# Altium schematic sheet 0_MCU_And_Uart.SchDoc
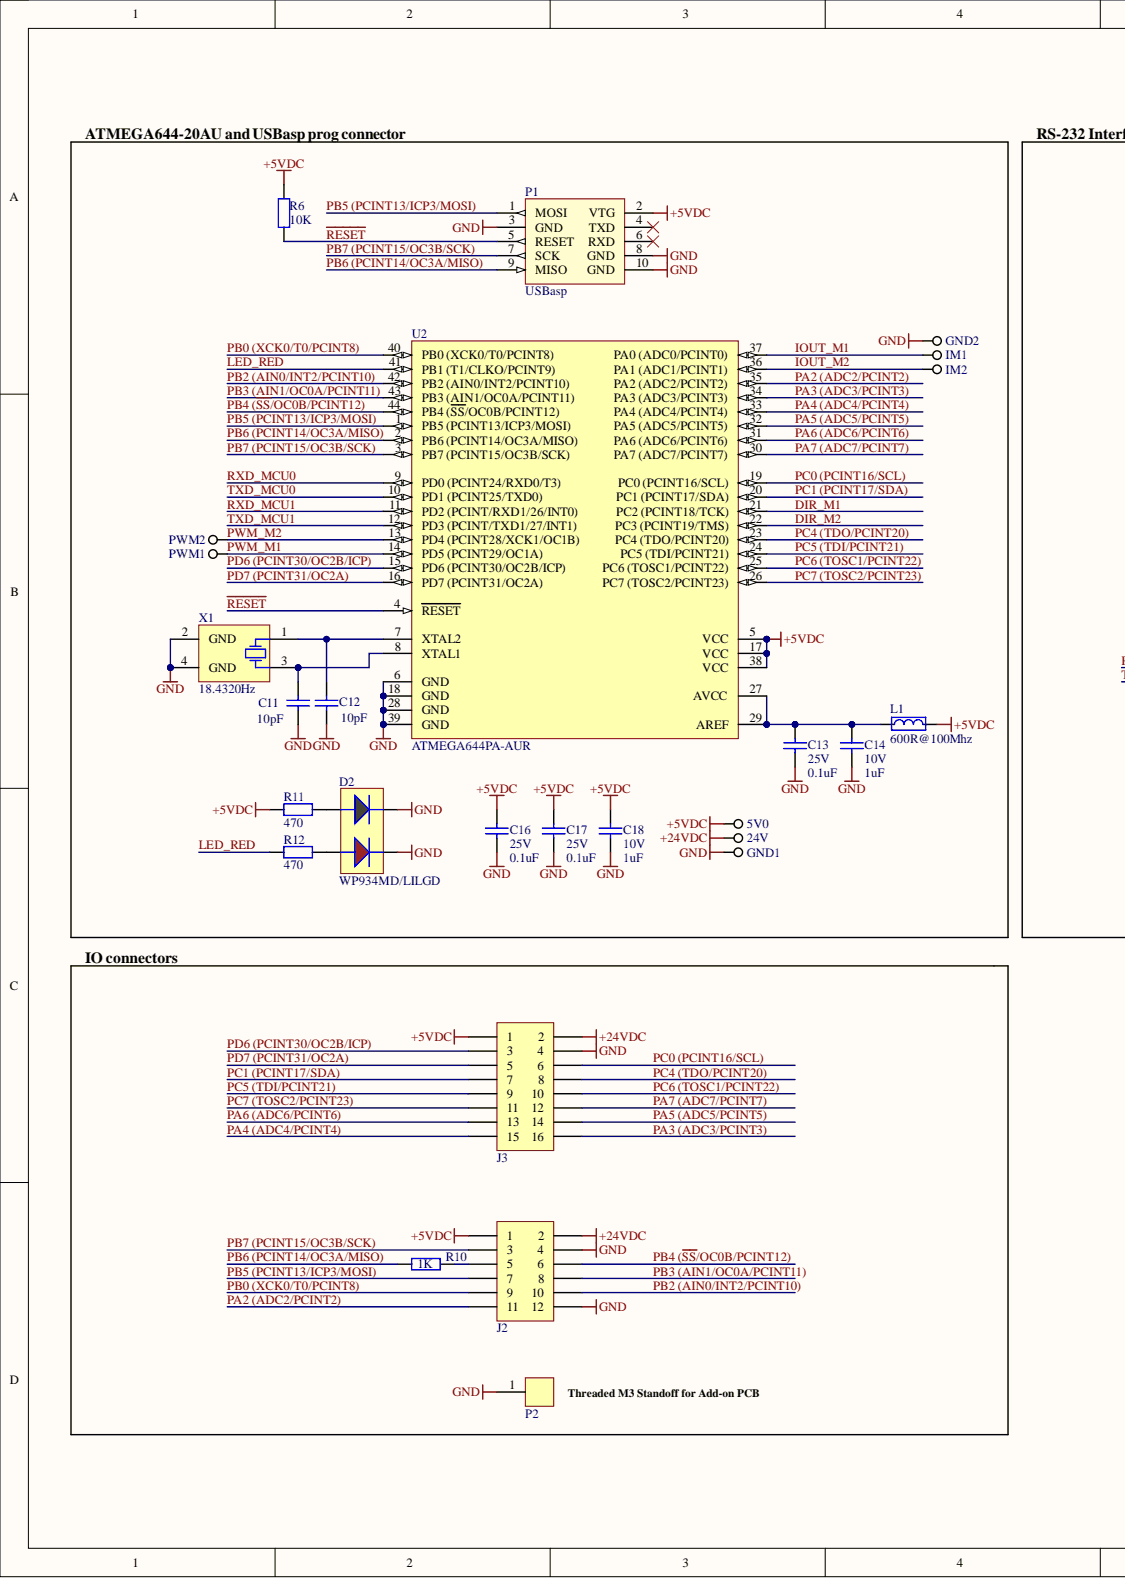
[diagram p0: 0_MCU_And_Uart.SchDoc, left]
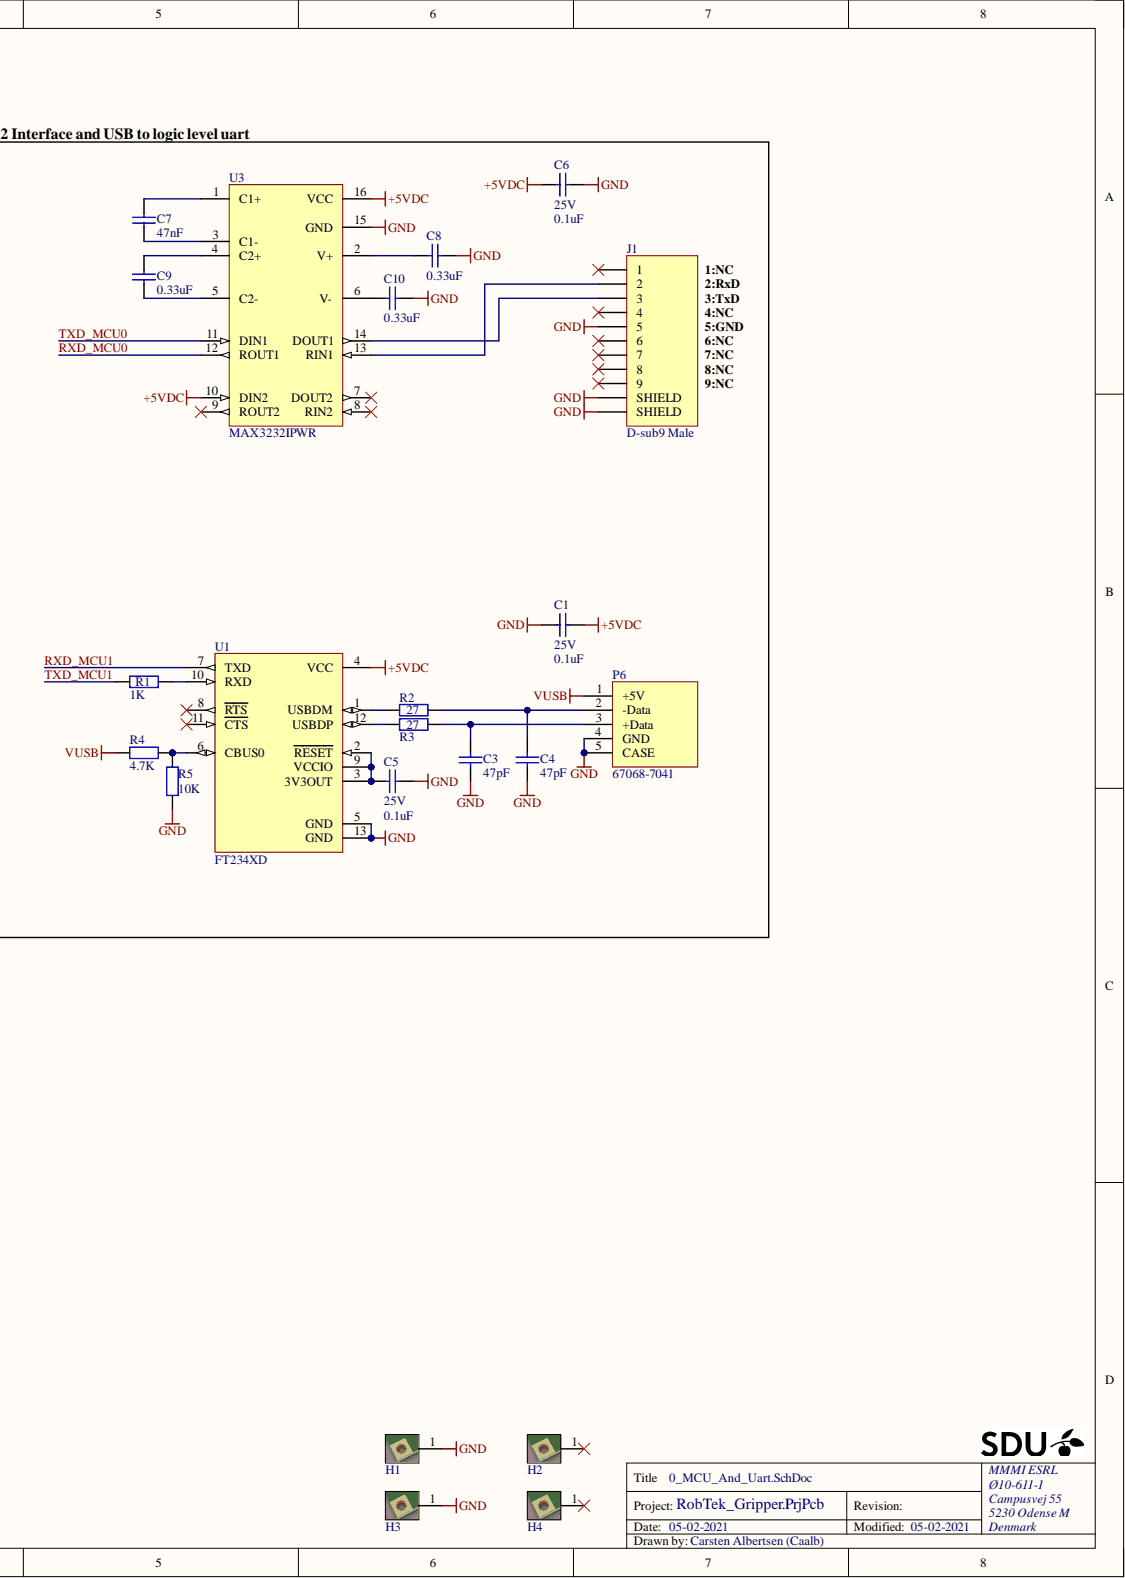
[diagram p1: 0_MCU_And_Uart.SchDoc, right]

## Parts
5V0  Test point SMD  [TestPointPadSMD_1mm]  (value not drawn)  (no BOM)
24V  Test point SMD  [TestPointPadSMD_1mm]  (value not drawn)  (no BOM)
C1  25V  value 0.1uF  [0603C]
C3  value 47pF  [0603C]  (hidden comment: 50V)
C4  value 47pF  [0603C]  (hidden comment: 50V)
C5  25V  value 0.1uF  [0603C]
C6  25V  value 0.1uF  [0603C]
C7  value 47nF  [0603C]  (hidden comment: 50V)
C8  value 0.33uF  [0603C]  (hidden comment: 50V)
C9  value 0.33uF  [0603C]  (hidden comment: 50V)
C10  value 0.33uF  [0603C]  (hidden comment: 50V)
C11  value 10pF  [0603C]  (hidden comment: 50V)
C12  value 10pF  [0603C]  (hidden comment: 50V)
C13  25V  value 0.1uF  [0603C]
C14  10V  value 1uF  [0603C]
C16  25V  value 0.1uF  [0603C]
C17  25V  value 0.1uF  [0603C]
C18  10V  value 1uF  [0603C]
D2  WP934MD/LILGD  [WP934MD/LILGD]
GND1  Test point SMD  [TestPointPadSMD_1mm]  (value not drawn)  (no BOM)
GND2  Test point SMD  [TestPointPadSMD_1mm]  (value not drawn)  (no BOM)
H1  PCB Hole  [Hole 3mm]  (value not drawn)  (no BOM)
H2  PCB Hole  [Hole 3mm]  (value not drawn)  (no BOM)
H3  PCB Hole  [Hole 3mm]  (value not drawn)  (no BOM)
H4  PCB Hole  [Hole 3mm]  (value not drawn)  (no BOM)
IM1  Test point SMD  [TestPointPadSMD_1mm]  (value not drawn)  (no BOM)
IM2  Test point SMD  [TestPointPadSMD_1mm]  (value not drawn)  (no BOM)
J1  D-sub9 Male  [A-DS 09 A/KG-T2S]
J2  2x6 Header  [HDR2X6 Receptacles]  (value not drawn)
J3  2x8 Header  [HDR2X8 Receptacles]  (value not drawn)
L1  600R@100Mhz  [0603L]
P1  USBasp  [HDR2X5 FLAD 90DEG 30310-5002HB]
P2  BUSH, M3, SMT  [9774110360R]  (value not drawn)
P6  67068-7041  [67068-7041]
PWM1  Test point SMD  [TestPointPadSMD_1mm]  (value not drawn)  (no BOM)
PWM2  Test point SMD  [TestPointPadSMD_1mm]  (value not drawn)  (no BOM)
R1  1%  value 1K  [0603R]
R2  value 27  [0603R]  (hidden comment: 1%)
R3  value 27  [0603R]  (hidden comment: 1%)
R4  value 4.7K  [0603R]  (hidden comment: 1%)
R5  1%  value 10K  [0603R]
R6  1%  value 10K  [0603R]
R10  1%  value 1K  [0603R]
R11  value 470  [0603R]  (hidden comment: 1%)
R12  value 470  [0603R]  (hidden comment: 1%)
U1  FT234XD  [DFN12 3X3]
U2  ATMEGA644PA-AUR  [TQFP44]
U3  MAX3232IPWR  [tssop16]
X1  18.4320Hz  [TSX-3225]

## Nets
+5VDC: 5V0.1 C1.2 C6.1 C16.2 C17.2 C18.2 J2.1 J3.1 L1.2 P1.2(VTG) R6.2 R11.2 U1.4(VCC) U2.5(VCC) U2.17(VCC) U2.38(VCC) U3.10(DIN2) U3.16(VCC)
+24VDC: 24V.1 J2.2 J3.2
GND: C1.1 C3.1 C4.1 C5.1 C6.2 C8.1 C10.1 C11.1 C12.1 C13.1 C14.1 C16.1 C17.1 C18.1 D2.2 D2.4 GND1.1 GND2.1 H1.1 H3.1 J1.5 J1.10(SHIELD) J1.11(SHIELD) J2.4 J2.12 J3.4 P1.3(GND) P1.8(GND) P1.10(GND) P2.1 P6.4(GND) P6.5(CASE) R5.1 U1.5(GND) U1.13(GND) U2.6(GND) U2.18(GND) U2.28(GND) U2.39(GND) U3.15(GND) X1.2(GND) X1.4(GND)
VUSB: P6.1(+5V) R4.2
DIR_M1: U2.21(PC2_(PCINT18/TCK))
DIR_M2: U2.22(PC3_(PCINT19/TMS))
IOUT_M1: IM1.1 U2.37(PA0_(ADC0/PCINT0))
IOUT_M2: IM2.1 U2.36(PA1_(ADC1/PCINT1))
LED_RED: R12.2 U2.41(PB1_(T1/CLKO/PCINT9))
PA2 (ADC2/PCINT2): J2.11 U2.35(PA2_(ADC2/PCINT2))
PA3 (ADC3/PCINT3): J3.16 U2.34(PA3_(ADC3/PCINT3))
PA4 (ADC4/PCINT4): J3.15 U2.33(PA4_(ADC4/PCINT4))
PA5 (ADC5/PCINT5): J3.14 U2.32(PA5_(ADC5/PCINT5))
PA6 (ADC6/PCINT6): J3.13 U2.31(PA6_(ADC6/PCINT6))
PA7 (ADC7/PCINT7): J3.12 U2.30(PA7_(ADC7/PCINT7))
PB0 (XCK0/T0/PCINT8): J2.9 U2.40(PB0_(XCK0/T0/PCINT8))
PB2 (AIN0/INT2/PCINT10): J2.10 U2.42(PB2_(AIN0/INT2/PCINT10))
PB3 (AIN1/OC0A/PCINT11): J2.8 U2.43(PB3_(AIN1/OC0A/PCINT11))
PB4 (~{SS}/OC0B/PCINT12): J2.6 U2.44(PB4_(SS/OC0B/PCINT12))
PB5 (PCINT13/ICP3/MOSI): J2.7 P1.1(MOSI) U2.1(PB5_(PCINT13/ICP3/MOSI))
PB6 (PCINT14/OC3A/MISO): P1.9(MISO) R10.2 U2.2(PB6_(PCINT14/OC3A/MISO))
PB7 (PCINT15/OC3B/SCK): J2.3 P1.7(SCK) U2.3(PB7_(PCINT15/OC3B/SCK))
PC0 (PCINT16/SCL): J3.6 U2.19(PC0_(PCINT16/SCL))
PC1 (PCINT17/SDA): J3.7 U2.20(PC1_(PCINT17/SDA))
PC4 (TDO/PCINT20): J3.8 U2.23(PC4_(TDO/PCINT20))
PC5 (TDI/PCINT21): J3.9 U2.24(PC5_(TDI/PCINT21))
PC6 (TOSC1/PCINT22): J3.10 U2.25(PC6_(TOSC1/PCINT22))
PC7 (TOSC2/PCINT23): J3.11 U2.26(PC7_(TOSC2/PCINT23))
PD6 (PCINT30/OC2B/ICP): J3.3 U2.15(PD6_(PCINT30/OC2B/ICP))
PD7 (PCINT31/OC2A): J3.5 U2.16(PD7_(PCINT31/OC2A))
PWM_M1: PWM1.1 U2.14(PD5_(PCINT29/OC1A))
PWM_M2: PWM2.1 U2.13(PD4_(PCINT28/XCK1/OC1B))
~{RESET}: P1.5(RESET) R6.1 U2.4(RESET)
RXD_MCU0: U2.9(PD0_(PCINT24/RXD0/T3)) U3.12(ROUT1)
RXD_MCU1: U1.7(TXD) U2.11(PD2_(PCINT/RXD1/26/INT0))
TXD_MCU0: U2.10(PD1_(PCINT25/TXD0)) U3.11(DIN1)
TXD_MCU1: R1.2 U2.12(PD3_(PCINT/TXD1/27/INT1))
NetC3_2: C3.2 P6.3(+Data) R3.1
NetC4_2: C4.2 P6.2(-Data) R2.1
NetC5_2: C5.2 U1.2(RESET) U1.3(3V3OUT) U1.9(VCCIO)
NetC7_1: C7.1 U3.3(C1-)
NetC7_2: C7.2 U3.1(C1+)
NetC8_2: C8.2 U3.2(V+)
NetC9_1: C9.1 U3.5(C2-)
NetC9_2: C9.2 U3.4(C2+)
NetC10_2: C10.2 U3.6(V-)
NetC11_2: C11.2 U2.8(XTAL1) X1.3
NetC12_2: C12.2 U2.7(XTAL2) X1.1
NetC13_2: C13.2 C14.2 L1.1 U2.27(AVCC) U2.29(AREF)
NetD2_1: D2.1 R12.1
NetD2_3: D2.3 R11.1
NetJ1_2: J1.2 U3.13(RIN1)
NetJ1_3: J1.3 U3.14(DOUT1)
NetJ2_5: J2.5 R10.1
NetR1_1: R1.1 U1.10(RXD)
NetR2_2: R2.2 U1.1(USBDM)
NetR3_2: R3.2 U1.12(USBDP)
NetR4_1: R4.1 R5.2 U1.6(CBUS0)

Not connected: H2 1; H4 1; J1 1 4 6 7 8 9; P1 4(TXD) 6(RXD); U1 8(RTS) 11(CTS); U3 7(DOUT2) 8(RIN2) 9(ROUT2)

## Library data of the parts
C1 C6 C13 C16 C17 = Cap_0603_.1uF: Capacitor, SMD, 0603, X7R; Component Kind: Standard; Simulation: CAP; Supplier 1: RS-Components; Supplier 2: Farnell; Supplier 3: Digi-Key; Supplier 4: Mouser; Library Name: Ofte_Benyttede.SCHLIB
C3 C4 C7-C12 = Cap_0603: Capacitor, SMD, 0603; Component Kind: Standard; Simulation: CAP; Supplier 1: RS-Components; Supplier 2: Farnell; Supplier 3: Digi-Key; Supplier 4: Mouser; Library Name: Ofte_Benyttede.SCHLIB
C5 = Cap_0603_.1uF: Capacitor, SMD, 0603, X7R; Value: 0.1uF; Component Kind: Standard; Simulation: CAP; Supplier 1: RS-Components; Supplier 2: Farnell; Supplier 3: Digi-Key; Supplier 4: Mouser; Library Name: Ofte_Benyttede.SCHLIB
C14 C18 = Cap_0603_1uF: Capacitor, SMD, 0603, X5R; Component Kind: Standard; Simulation: CAP; Supplier 1: RS-Components; Supplier 2: Farnell; Supplier 3: Digi-Key; Supplier 4: Mouser; Library Name: Ofte_Benyttede.SCHLIB
D2 = WP934MD/LILGD: LED Circuit Board Indicator 2 High Green (x 1), Red (x 1) Diffused, Tinted 1.9V Green, 1.7V Red 25mA Green, 30mA Red; Component Kind: Standard; Supplier 1: RS-Components; Supplier 2: Farnell; Supplier 3: Digi-Key; Supplier 4: Mouser; Supplier Part Number 3: 754-2010-ND; Library Name: DivLib.SCHLIB
H1-H4 = PCB Mounting Hole: Description: PCB Mounting Hole; Supplier 1: RS-Components; Supplier 2: Farnell; Supplier 3: Digi-Key; Supplier 4: Mouser; Library Name: Ofte_Benyttede.SCHLIB
J1 = A-DS 09 A/KG-T2S: 9 Position D-Sub Plug, Male Pins Connector; Component Kind: Standard; Supplier 1: RS-Components; Supplier 2: Farnell; Supplier 3: Digi-Key; Supplier 4: Mouser; Supplier Part Number 3: AE10968-ND; Library Name: Connector_Div_egne.SchLib
J2 = 2x6 Header: Header Male 2x6, PPPC062LFBN-RC; Component Kind: Standard; Supplier 1: RS-Components; Supplier 2: Farnell; Supplier 3: Digi-Key; Supplier 4: Mouser; Library Name: Connector_Div_egne.SchLib
J3 = 2x8 Header: Header Male 2x8, PPPC082LFBN-RC; Component Kind: Standard; Supplier 1: RS-Components; Supplier 2: Farnell; Supplier 3: Digi-Key; Supplier 4: Mouser; Supplier Part Number 3: S7111-ND; Library Name: Connector_Div_egne.SchLib
L1 = Ferrite_0603: Ferrite bead 1A, 200mOhm, 600R@100Mhz; Component Kind: Standard; Supplier 1: RS-Components; Supplier 2: Farnell; Supplier 3: Digi-Key; Supplier 4: Mouser; Supplier Part Number 3: 732-1593-1-ND; Value: 600R@100Mhz; Library Name: Ofte_Benyttede.SCHLIB
P1 = USBasp: USBasp programmer connector; Component Kind: Standard; Supplier 1: RS-Components; Supplier 2: Farnell; Supplier 3: Digi-Key; Supplier 4: Mouser; Supplier Part Number 3: 3M15452-ND; Library Name: DivLib.SCHLIB
P2 = 9774110360R: Round Standoff Threaded M3x0.5 Steel 0.157" (11.00mm); Component Kind: Standard; Supplier 1: RS-Components; Supplier 2: Farnell; Supplier 3: Digi-Key; Supplier 4: Mouser; Supplier Part Number 3: 732-5278-1-ND; Library Name: DivLib.SCHLIB
P6 = 67068-7041: USB TYPE B, RECEPTACLE, R/A, THT; Lager: No; Component Kind: Standard; ComponentLink1Description: http://www.molex.com/pdm_docs/sd/670687041_sd.pdf; ComponentLink1URL: http://www.molex.com/pdm_docs/sd/670687041_sd.pdf; Supplier 1: RS-Components; Supplier 2: Farnell; Supplier 3: Digi-Key; Supplier 4: Mouser; Supplier Part Number 1: 515-1989; Supplier Part Number 2: 2063740; Supplier Part Number 3: WM3463-ND; Library Name: Molex_egne.SchLib
R1-R6 R10-R12 = Res_0603: Resistor, SMD, 0603, 0.063W; Component Kind: Standard; Simulation: RESISTOR; Supplier 1: RS-Components; Supplier 2: Farnell; Supplier 3: Digi-Key; Supplier 4: Mouser; Library Name: Ofte_Benyttede.SCHLIB
  R2 R3: Supplier Part Number 3: RMCF0603FT27R0CT-ND
U1 = FT234XD: IC USB SERIAL BASIC UART 12DFN; Component Kind: Standard; ComponentLink1Description: http://www.ftdichip.com/Support/Documents/DataSheets/ICs/DS_FT234XD.pdf; ComponentLink1URL: http://www.ftdichip.com/Support/Documents/DataSheets/ICs/DS_FT234XD.pdf; Supplier 1: RS-Components; Supplier 2: Farnell; Supplier 3: Digi-Key; Supplier 4: Mouser; Supplier Part Number 1: 888-8748; Supplier Part Number 2: 2419911; Supplier Part Number 3: 768-1178-1-ND; Library Name: FTDI_egne.SchLib
U2 = ATMEGA644PA-AUR: AVR AVR® ATmega Microcontroller IC 8-Bit 20MHz 64KB (32K x 16) FLASH 44-TQFP (10x10); Component Kind: Standard; Supplier 1: RS-Components; Supplier 2: Farnell; Supplier 3: Digi-Key; Supplier 4: Mouser; Supplier Part Number 3: ATMEGA644PA-AURCT-ND; Library Name: Atmel_egne.SchLib
U3 = MAX3232IPWR: MAX3232 3-V to 5.5-V Multichannel RS-232 Line Driver/Receiver  With ±15-kV ESD Protection; Component Kind: Standard; Supplier 1: RS-Components; Supplier 2: Farnell; Supplier 3: Digi-Key; Supplier 4: Mouser; Supplier Part Number 3: 296-13100-1-ND; Library Name: Texas_inst_egne.SchLib
X1 = FA-238 18.4320MB-C3: 18.432MHz ±50ppm Crystal 18pF 80 Ohms 4-SMD, No Lead; Component Kind: Standard; ComponentLink1Description: http://www.txccrystal.com/images/pdf/number-crystal-basic.pdf; ComponentLink1URL: http://www.txccrystal.com/images/pdf/number-crystal-basic.pdf; ComponentLink2Description: http://www.txccrystal.com/images/pdf/7a.pdf; ComponentLink2URL: http://www.txccrystal.com/images/pdf/7a.pdf; Supplier 1: RS-Components; Supplier 2: Farnell; Supplier 3: Digi-Key; Supplier 4: Mouser; Supplier Part Number 3: SER3687CT-ND; Library Name: DivLib.SCHLIB
5V0 24V GND1 GND2 IM1 IM2 PWM1 PWM2 = Test point SMD: Description: Test point SMD; Library Name: DivLib.SCHLIB
